# Altium schematic sheet Second Band Filter.SchDoc
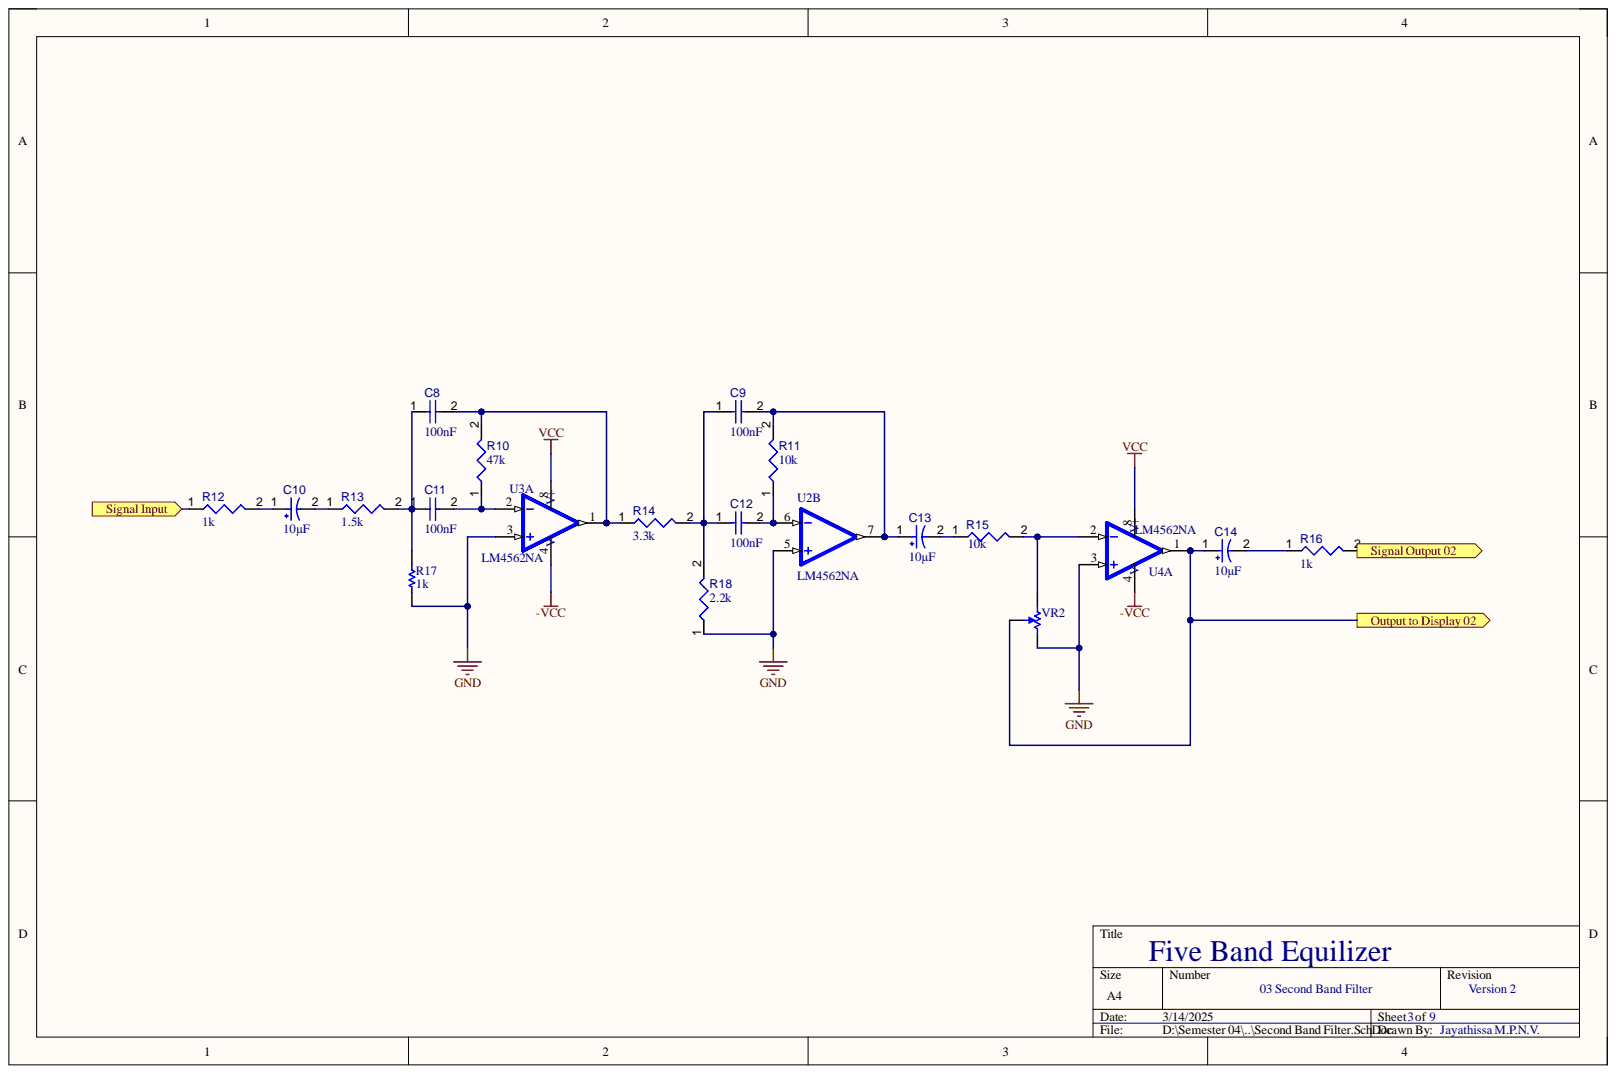
[diagram p0: Second Band Filter.SchDoc]

## Parts
C8  value 100nF  [CAPC1005X56X25NL10T15]  (hidden comment: Capacitor 6.8 nF +/- 10% 16 V 0402)
C9  value 100nF  [CAPC0402(1005)60_L]  (hidden comment: Capacitor 22nF +/-20% 10V 0402)
C10  value 10µF  [PNSC-6TPE150MAZB_L]  (hidden comment: Capacitor 6.8µF +/-20% 35V B2)
C11  value 100nF  [CAPC1005X56X25NL10T15]  (hidden comment: Capacitor 6.8 nF +/- 10% 16 V 0402)
C12  value 100nF  [CAPC0402(1005)60_L]  (hidden comment: Capacitor 22nF +/-20% 10V 0402)
C13  value 10µF  [PNSC-6TPE150MAZB_L]  (hidden comment: Capacitor 6.8µF +/-20% 35V B2)
C14  value 10µF  [PNSC-6TPE150MAZB_L]  (hidden comment: Capacitor 6.8µF +/-20% 35V B2)
R10  value 47k  [RESC0402(1005)_L]  (hidden comment: Resistor 232K +/-1 % 0402 63 mW)
R11  value 10k  [RESC0402(1005)_L]  (hidden comment: Resistor 47K5 +/-1 % 0402 63 mW)
R12  value 1k  [RESC0805(2012)_L]  (hidden comment: Resistor 1K +/-5% 0805 125 mW)
R13  value 1.5k  [RESC0402(1005)_L]  (hidden comment: Resistor 47K5 +/-1 % 0402 63 mW)
R14  value 3.3k  [RESC1005X40X25ML10T10]  (hidden comment: Resistor 15k +/-1% 0402 63 mW)
R15  value 10k  [RESC0402(1005)_L]  (hidden comment: Resistor 10K  +/-1 % 0402 63 mW)
R16  value 1k  [RESC0805(2012)_L]  (hidden comment: Resistor 1K +/-5% 0805 125 mW)
R17  value 1k  [RESC1005X40X25ML05T10]  (hidden comment: Resistor 51k +/-5% 0402 63 mW)
R18  value 2.2k  [RESC1005X40X25ML10T10]  (hidden comment: Resistor 15k +/-1% 0402 63 mW)
U2  LM4562NA  [DIP794W45P254L959H508Q8]  (part B)
U3  LM4562NA  [DIP794W45P254L959H508Q8]  (part A)
U4  LM4562NA  [DIP794W45P254L959H508Q8]  (part A)
VR2  3296W-1-102LF  [BOUR-3296W-1-3]  (value not drawn)

## Ports
Output to Display 02, Signal Input, Signal Output 02

## Nets
-VCC: U3.4 U4.4
GND: R17.1 R18.1 U2.5 U3.3(V-) U4.3(V-) VR2.2
VCC: U3.8 U4.8
Output to Display 02: C14.1 U4.1 VR2.3 ; port Output to Display 02
Signal Input: R12.1 ; port Signal Input
Signal Output 02: R16.2 ; port Signal Output 02
NetC8_1: C8.1 C11.1 R13.2 R17.2
NetC8_2: C8.2 R10.2 R14.1 U3.1
NetC9_1: C9.1 C12.1 R14.2 R18.2
NetC9_2: C9.2 C13.1 R11.2 U2.7
NetC10_1: C10.1 R12.2
NetC10_2: C10.2 R13.1
NetC11_2: C11.2 R10.1 U3.2
NetC12_2: C12.2 R11.1 U2.6
NetC13_2: C13.2 R15.1
NetC14_2: C14.2 R16.1
NetR15_2: R15.2 U4.2 VR2.1

## Library data of the parts
C8 C11 = CMP-001-00000-6: Chip Capacitor, 6.8 nF, +/- 10%, 16 V, 0402 (1005 Metric); Capacitor Type: Ceramic; Voltage Rating: 16V; Case/Package: 0402; Component Kind: Standard; Max Operating Temperature: 125°C; Min Operating Temperature: -55°C; Mounting Technology: SM; Pins: 2; RoHS Compliant: True; Tolerance: 10%; Library Name: Nirwan Jayathissa
C9 C12 = CMP-001-00062-3: Chip Capacitor, 22nF +/-20%, 10V, 0402, Thickness 0.6 mm; Tolerance: 10%; Case/Package: 0402; Component Kind: Standard; Max Operating Temperature: 85°C; Min Operating Temperature: -55°C; Mounting Technology: SMT; Pins: 2; Voltage Rating: 10V; Library Name: Nirwan Jayathissa
C10 C13 C14 = CMP-001-00059-3: Molded Tantalum Polymer Capacitor, 6.8 uF, +/- 20%, 35 V, -55 to 105 degC, 2-Pin SMD, RoHS, Tape and Reel; Case/Package: 1210; Component Kind: Standard; Max Operating Temperature: 105°C; Min Operating Temperature: -55°C; Mounting Technology: SMT; Pins: 2; Tolerance: 20%; Voltage Rating: 35V; Library Name: Nirwan Jayathissa
R10 = CMP-009-00127-3: Chip Resistor, 232 kOhms, +/-1 %, 63 mW, -55 to 155 degC, 0402; Power: 63mW; Tolerance: 1%; Case/Package: 0402; Component Kind: Standard; Pins: 2; Library Name: Nirwan Jayathissa
R11 R13 = CMP-009-00137-3: Chip Resistor, 47,5 kOhms, +/-1 %, 63 mW, -55 to 155 degC, 0402; Power: 63mW; Tolerance: 1%; Case/Package: 0402; Component Kind: Standard; Max Operating Temperature: 155°C; Min Operating Temperature: -55°C; Pins: 2; Library Name: Nirwan Jayathissa
R12 R16 = CMP-009-00118-3: Chip Resistor, 1 kOhms, +/-5%, 125 mW, -55 to 155 degC, 0805; Power: 125mW; Tolerance: 5%; Case/Package: 0805; Component Kind: Standard; Max Operating Temperature: 155°C; Min Operating Temperature: -55°C; Pins: 2; Library Name: Nirwan Jayathissa
R14 R18 = CMP-009-00026-5: Chip Resistor, 15 KOhm, +/- 1%, 0.063 W, -55 to 155 degC, 0402 (1005 Metric); Power: 63mW; Case/Package: 0402; Component Kind: Standard; Max Operating Temperature: 155°C; Min Operating Temperature: -55°C; Mounting Technology: SM; Pins: 2; RoHS Compliant: True; Tolerance: 1%; Library Name: Nirwan Jayathissa
R15 = CMP-009-00149-3: Chip Resistor, 10 kOhms, +/-1 %, 63 mW, -55 to 125 degC, 0402; Power: 63mW; Tolerance: 1%; Case/Package: 0402; Component Kind: Standard; Max Operating Temperature: 155°C; Min Operating Temperature: -55°C; Pins: 2; Library Name: Nirwan Jayathissa
R17 = CMP-009-00000-5: Chip Resistor, 51 KOhm, +/- 5%, 0.063 W, -55 to 155 degC, 0402 (1005 Metric); Power: 63mW; Case/Package: 0402; Component Kind: Standard; Max Operating Temperature: 155°C; Min Operating Temperature: -55°C; Mounting Technology: SM; Pins: 2; RoHS Compliant: True; Tolerance: 5%; Library Name: Nirwan Jayathissa
U2-U4 = CMP-014-00002-1: LM4562 2 - Dual Channels per IC Audio Amplifier Evaluation Board; MF: Texas Instruments; Availability: In Stock; Case/Package: DIP-8 Texas Instruments; Check_prices: https://www.snapeda.com/parts/LM4562NA/Texas+Instruments/view-part/?ref=eda; Component Kind: Standard; Component Type: Standard; Design Item ID: LM4562NA; MP: LM4562NA; Pins: 8; Price: None; Purchase-URL: https://pricing.snapeda.com/search/part/LM4562NA/?ref=eda; SnapEDA_Link: https://www.snapeda.com/parts/LM4562NA/Texas+Instruments/view-part/?ref=snap; Source: LM4562NA.SchLib; Library Name: Nirwan Jayathissa
VR2 = CMP-009-00037-2: Square Trimpot(R) Trimming Potentiometer, 1000 Ohm, -55 to 125 degC, 3-Pin THD, RoHS, Tube; Manufacturer: Bourns; Power: 500mW; Resistance: 1k; Tolerance: 10%; Voltage Rating: 300V; Case/Package: 3296W-1-3; Component Kind: Standard; Composition: Potentiometer; Datasheet URL: http://www.bourns.com/docs/Product-Datasheets/3296.pdf; Datasheet Version: May-16; Manufacturer URL: http://www.bourns.com/; Max Operating Temperature: 150°C; Min Operating Temperature: -55°C; Mounting Technology: TH; Package Description: 3-Pin Through Hole Device, Body 9.53 x 4.83 mm, Pitch 2.54 mm; Package Quantity: 50; Package Reference: 3296W-1-3; Packaging: Rail/Tube; RoHS Compliant: True; Library Name: Nirwan Jayathissa
